# Altium schematic sheet Processor_Interface.SchDoc
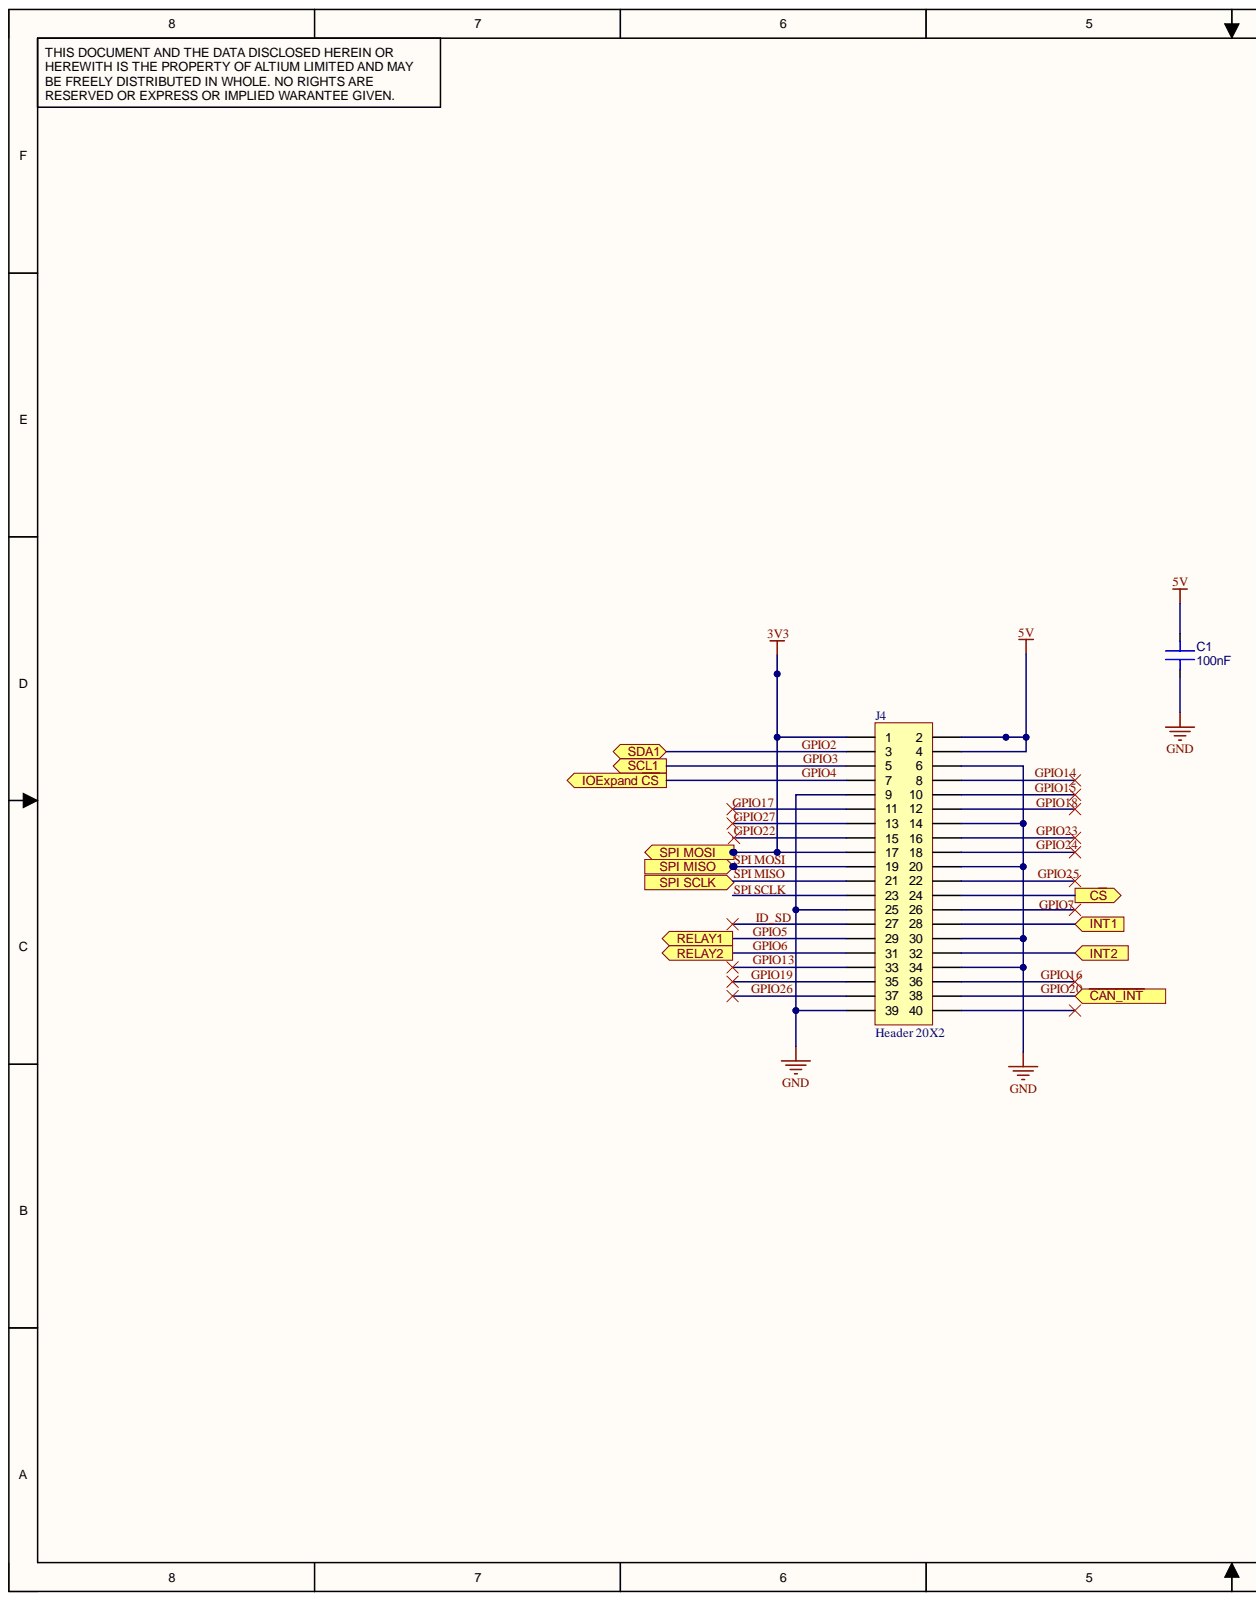
[diagram p0: Processor_Interface.SchDoc, left]
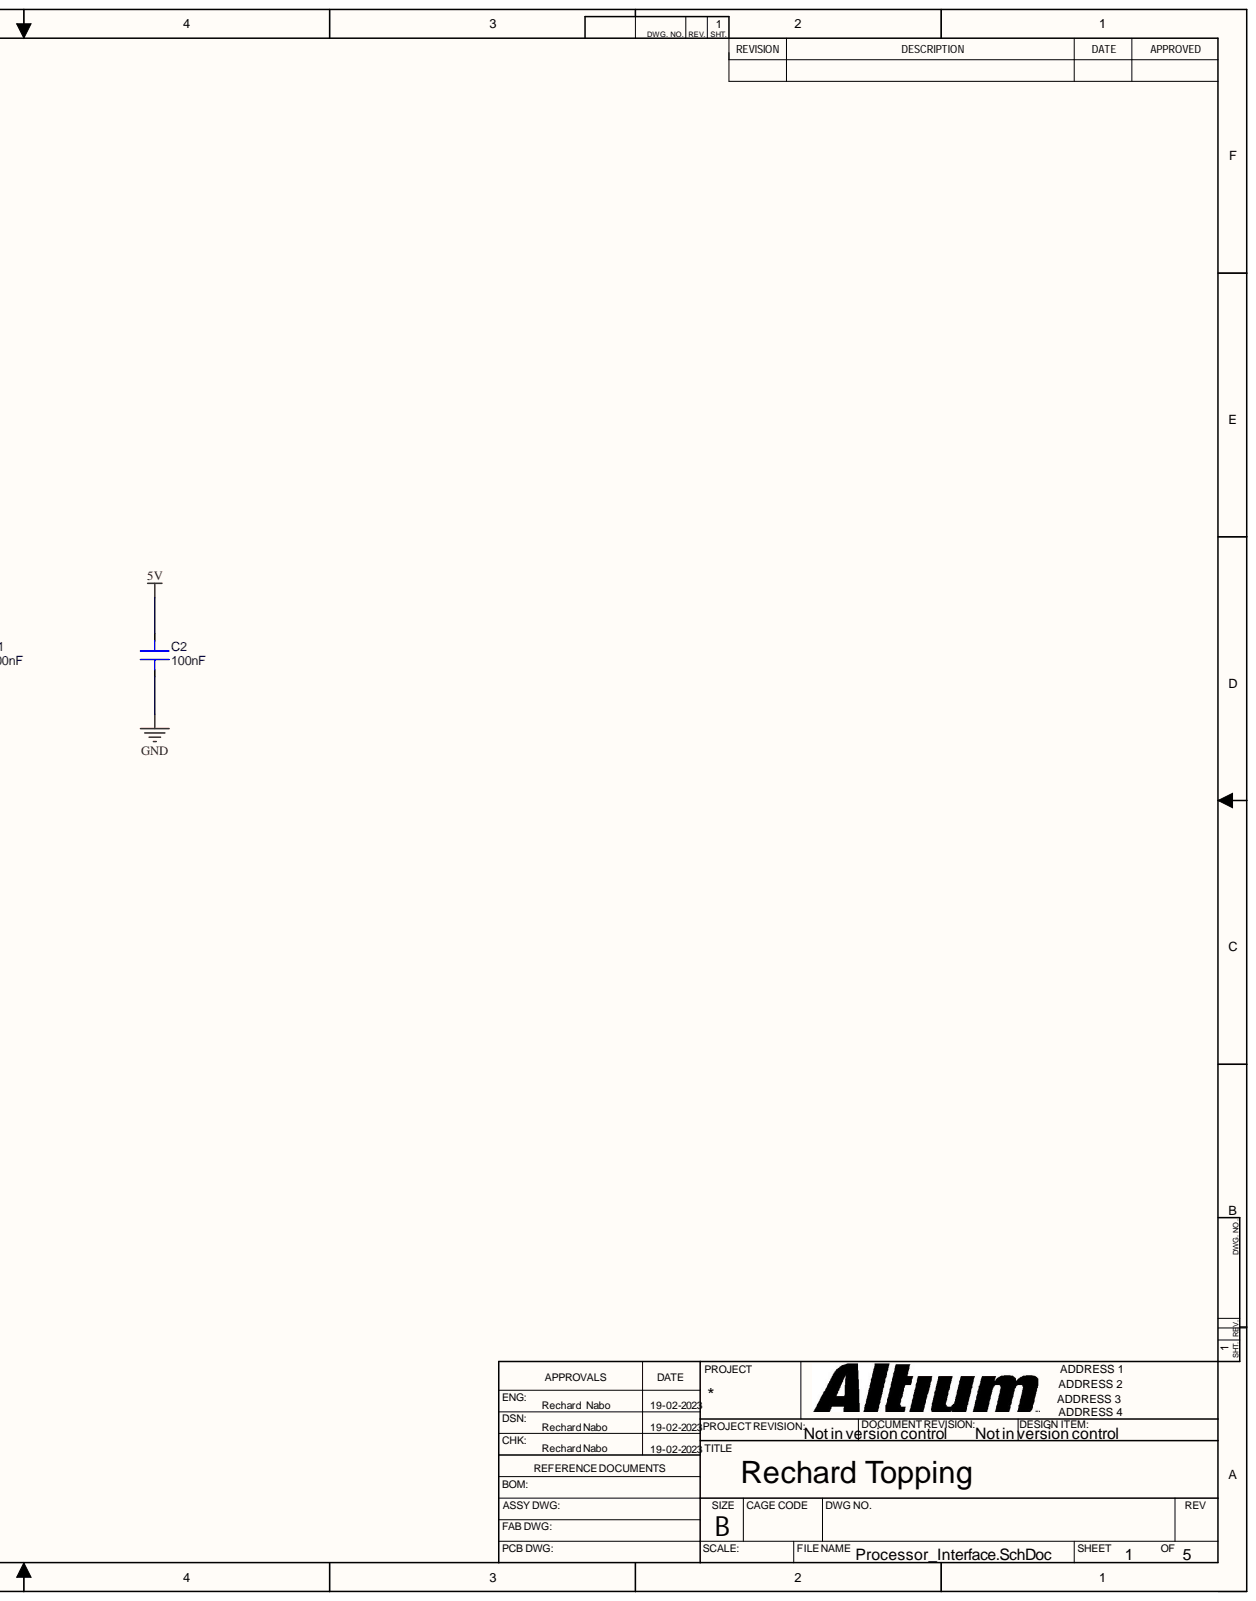
[diagram p1: Processor_Interface.SchDoc, right]

## Parts
C1  100nF  [ALTIUM_CHPC0603]
C2  100nF  [ALTIUM_CHPC0603]
J4  Header 20X2  [HDR2X20]

## Ports
C~{S}, INT1, INT2, IOExpand ~{CS}, RELAY1, RELAY2, SCL1, SDA1, SPI MISO, SPI MOSI, SPI SCLK, ~{CAN_INT}

## Nets
5V: C1.2 C2.2 J4.2 J4.4
GND: C1.1 C2.1 J4.6 J4.9 J4.14 J4.20 J4.25 J4.30 J4.34 J4.39
3V3: J4.1 J4.17 ; port SPI MOSI
C~{S}: J4.24 ; port C~{S}
GPIO2: J4.3 ; port SDA1
GPIO3: J4.5 ; port SCL1
GPIO4: J4.7 ; port IOExpand ~{CS}
GPIO5: J4.29 ; port RELAY1
GPIO6: J4.31 ; port RELAY2
GPIO13: J4.33
GPIO14: J4.8
GPIO15: J4.10
GPIO16: J4.36
GPIO17: J4.11
GPIO18: J4.12
GPIO19: J4.35
GPIO20: J4.38 ; port ~{CAN_INT}
GPIO22: J4.15
GPIO23: J4.16
GPIO24: J4.18
GPIO25: J4.22
GPIO26: J4.37
GPIO27: J4.13
ID_SD: J4.27
INT1: J4.28 ; port INT1
INT2: J4.32 ; port INT2
SPI MISO: J4.21
SPI MOSI: J4.19 ; port SPI MISO
GPIO7:
N00001: ; port SPI SCLK
SPI SCLK:

Not connected: J4 23 26 40

## Library data of the parts
C1 C2 = C0603_100n_±5%_25V_X7R: CAP; 100nF ±5% 25V CERAMIC CHIP X7R (0603); Manufacture Part Number: C0603C104C5GAC; Commodity No: 13 106 033; Drawn: MAKN; IC: L; UOM: PC; Component Kind: Standard; ComponentLink1Description: Kemet Datasheet; ComponentLink1URL: \\PCB-Server\Altium Libraries\Datasheets\Capacitors\Kemet\KEM_C1003_C0G_SMD.pdf; ComponentLink2Description: Kemet Link; ComponentLink2URL: http://www.kemet.com/; Dielectric: X7R; HelpURL: \\pcb-server\altium libraries\datasheets\KEM_C1003_C0G_SMD.pdf; LatestRevisionDate: 12-Dec-2013; Library Name: Passive - Capacitor 0603.SchLib; PackageReference: 0603; SMD: YES; Tolerance: ±5%; Value: 100nF; Volts: 25V
J4 = Header 20X2: Header, 20-Pin, Dual row; Component Kind: Standard; LatestRevisionDate: 17-Jul-2002; LatestRevisionNote: Re-released for DXP Platform.; Library Name: Miscellaneous Connectors.IntLib; Signal Integrity: Connector; Publisher: Altium Limited
